# Altium schematic sheet ESPLink.SchDoc
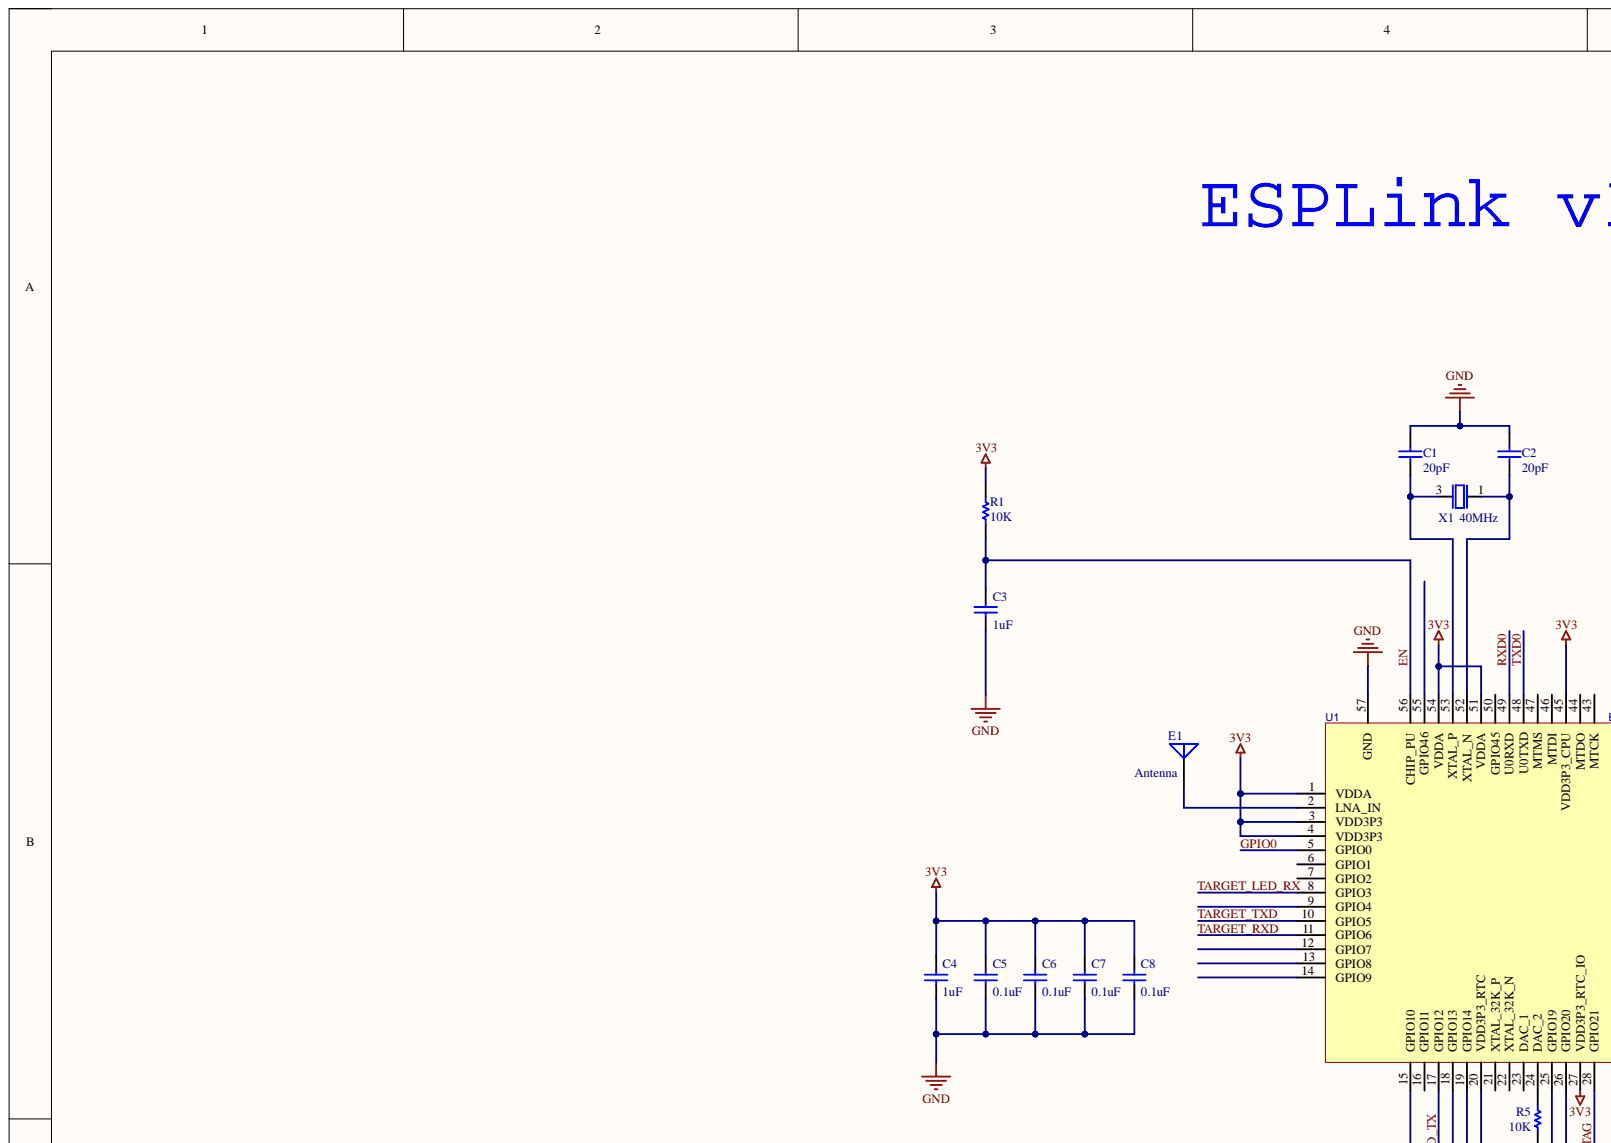
[diagram p0: ESPLink.SchDoc, top left]
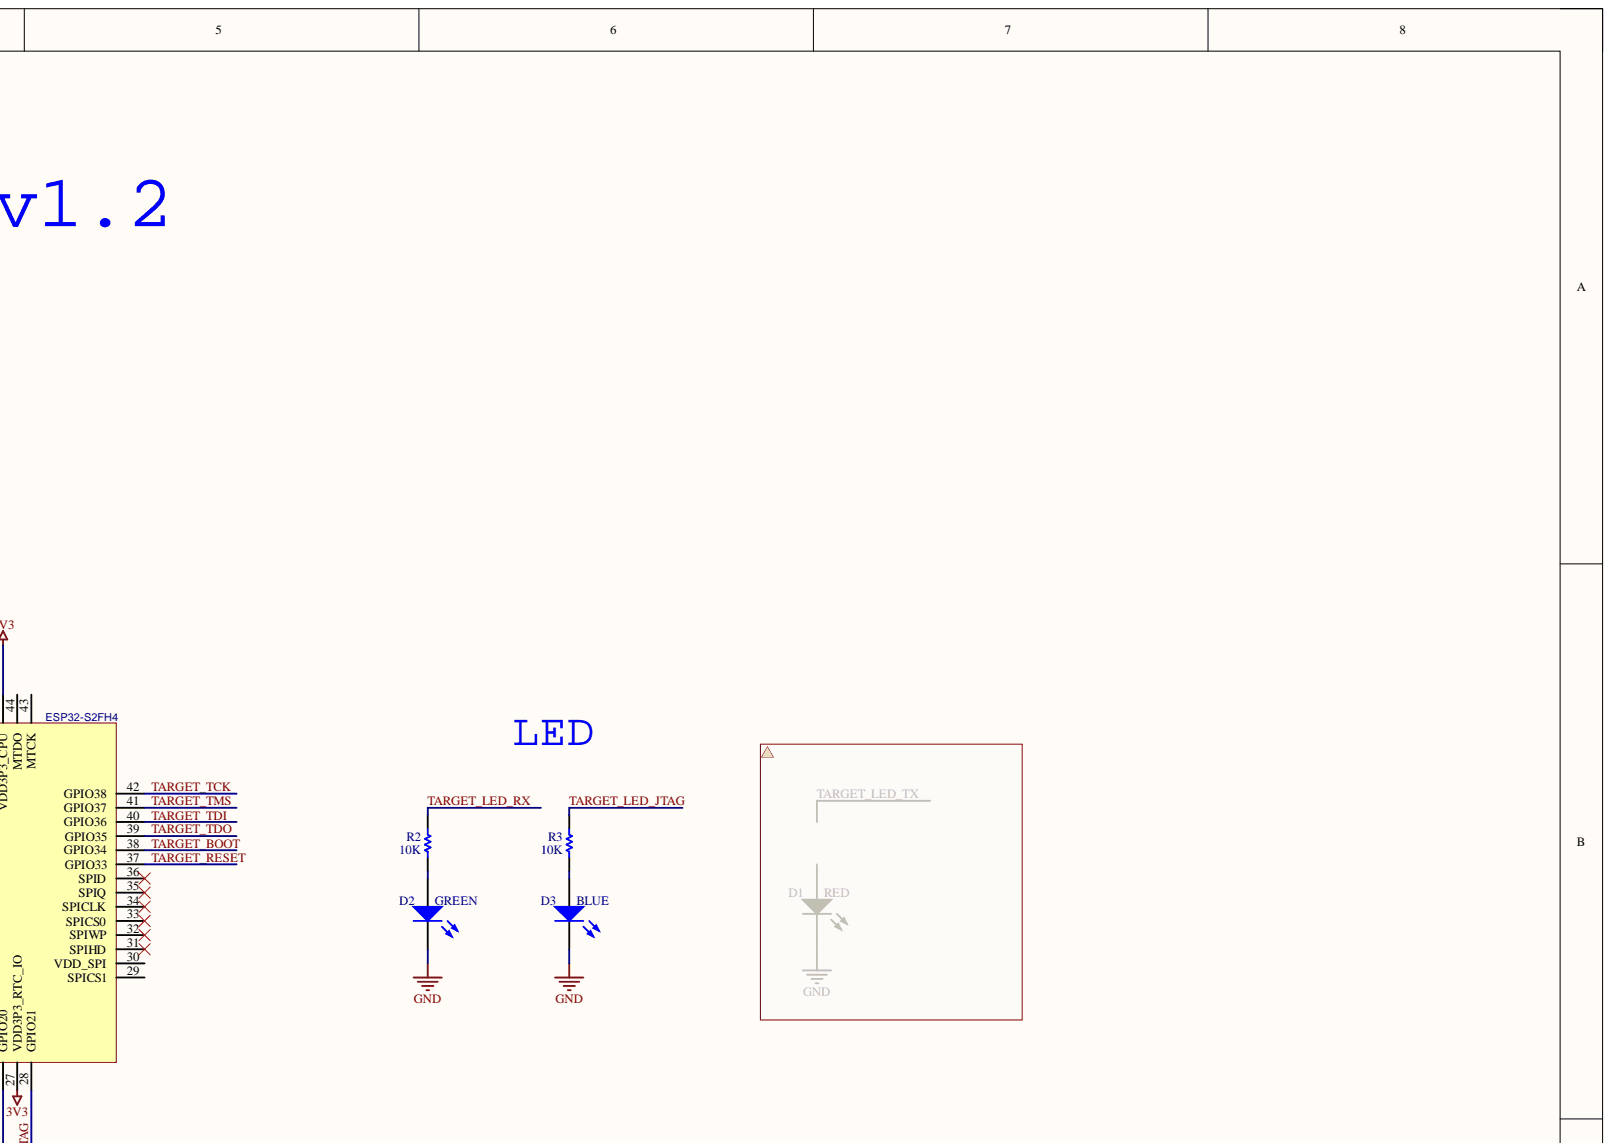
[diagram p1: ESPLink.SchDoc, top right]
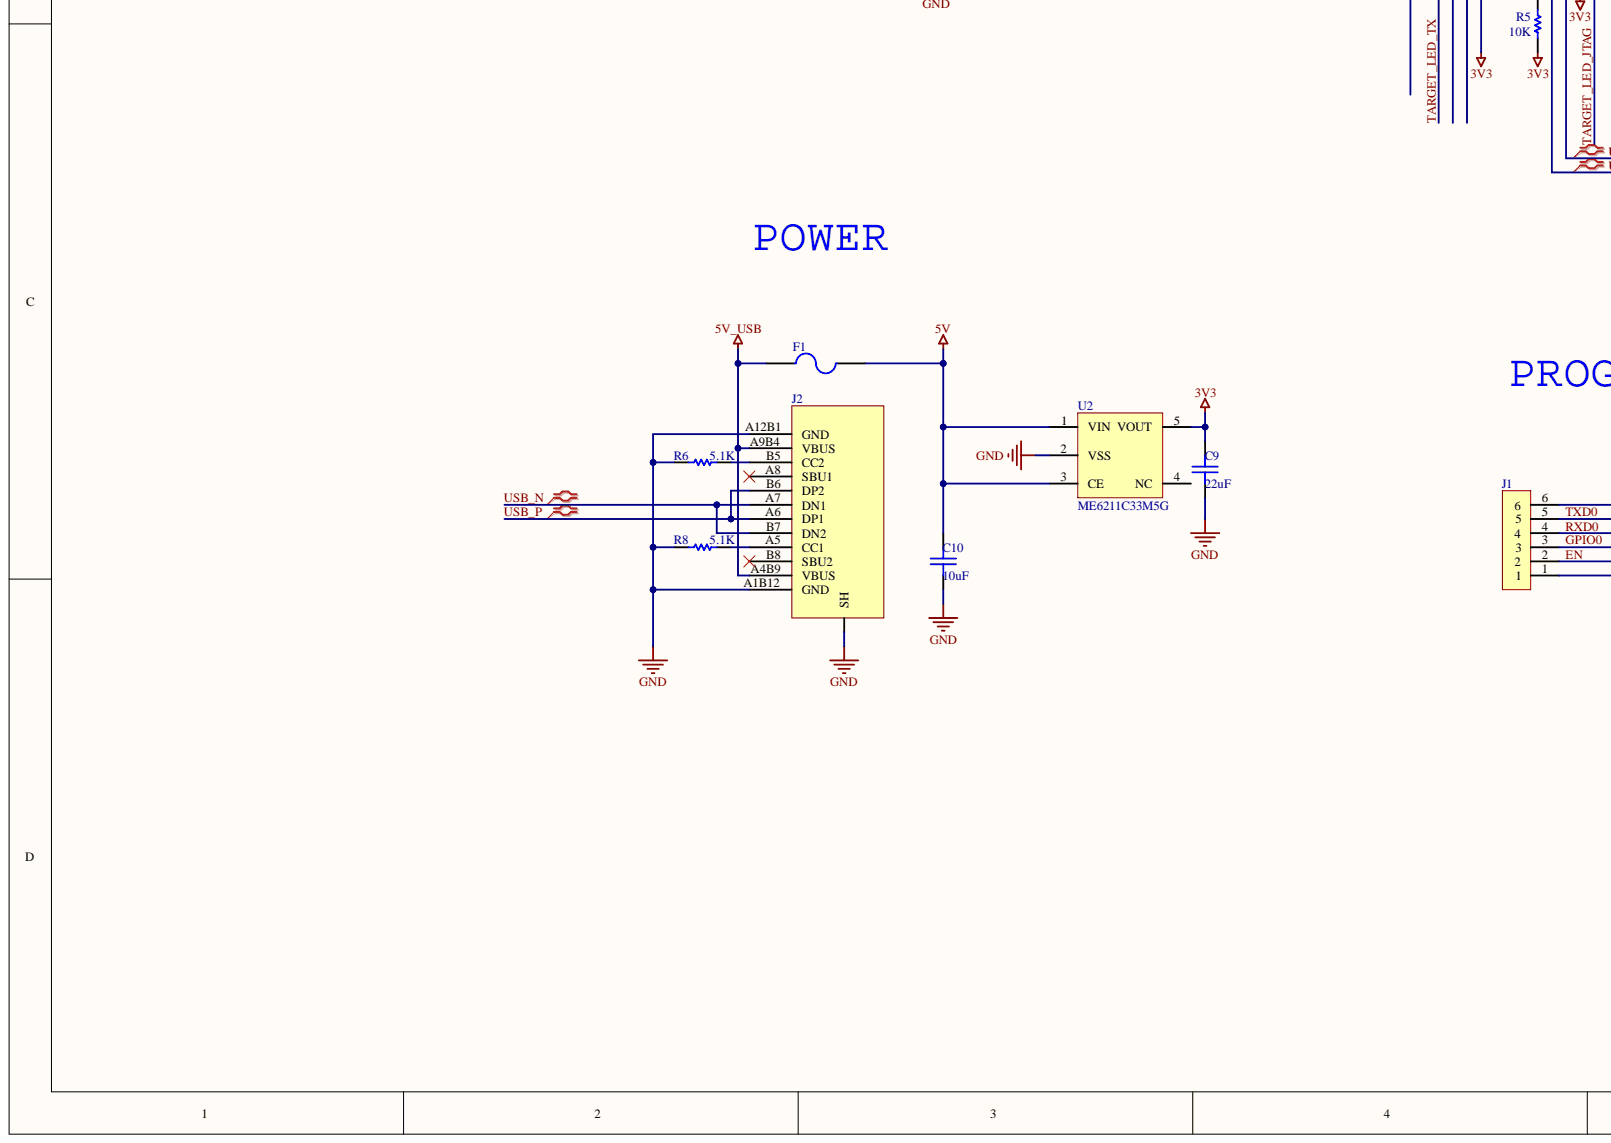
[diagram p2: ESPLink.SchDoc, bottom left]
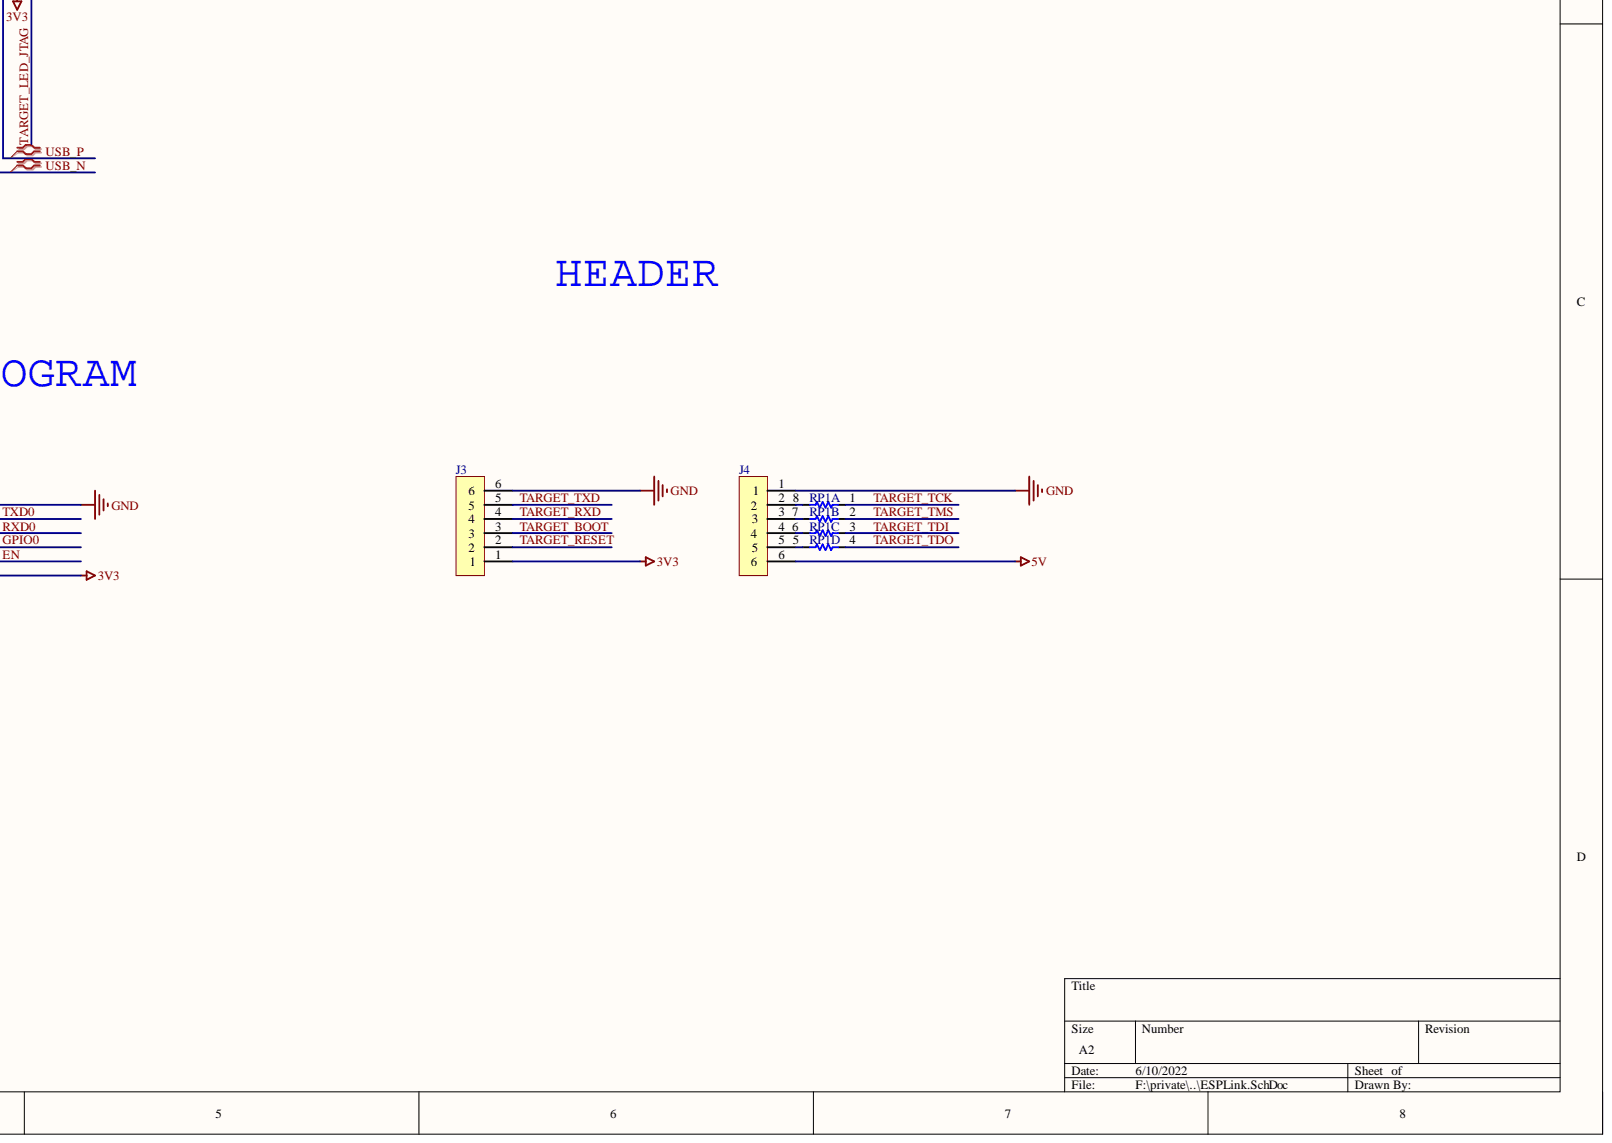
[diagram p3: ESPLink.SchDoc, bottom right]

## Parts
C1  20pF  [C 0402_M]
C2  20pF  [C 0402_M]
C3  1uF  [C 0402_M]
C4  1uF  [C 0402_M]
C5  0.1uF  [C 0402_M]
C6  0.1uF  [C 0402_M]
C7  0.1uF  [C 0402_M]
C8  0.1uF  [C 0402_M]
C9  22uF  [0603_C]
C10  10uF  [0603_C]
D2  GREEN  [0603_LED]
D3  BLUE  [0603_LED]
E1  Antenna  [ANT-Rainsun-AN2051]
F1  Fuse 2  [FU 0805]  (value not drawn)
J1  HEADER-6  [HEADER_1.27_SMT_6x1]  (value not drawn)
J2  Type_C_16P  [Type_C_16P]  (value not drawn)
J3  HEADER-6  [HEADER_SMT_6]  (value not drawn)
J4  HEADER-6  [HEADER_SMT_6]  (value not drawn)
R1  value 10K  [R 0402_M]  (hidden comment: R0603)
R2  10K  [R 0402_M]
R3  10K  [R 0402_M]
R5  value 10K  [R 0402_M]  (hidden comment: R0603)
R6  5.1K  [R 0402_M]
R8  5.1K  [R 0402_M]
RP1  R_pack  [RCA-8P4R-0402]  (value not drawn)  (parts A,B,C,D)
U1  ESP32-S2FH4  [QFN-56_L7.0-W7.0-P0.40-TL-EP4.0]
U2  ME6211C33M5G  [SOT23-5]
X1  40MHz  [BT-XTAL_3225]

## Nets
3V3: C4.2 C5.2 C6.2 C7.2 C8.2 C9.1 J1.1 J3.1 R1.1 R5.2 U1.1(VDDA) U1.3(VDD3P3) U1.4(VDD3P3) U1.20(VDD3P3_RTC) U1.27(VDD3P3_RTC_IO) U1.45(VDD3P3_CPU) U1.51(VDDA) U1.54(VDDA) U2.5(VOUT)
5V: C10.1 F1.2 J4.6 U2.1(VIN) U2.3(CE)
5V_USB: F1.1 J2.A4B9(VBUS) J2.A9B4(VBUS)
GND: C1.2 C2.2 C3.1 C4.1 C5.1 C6.1 C7.1 C8.1 C9.2 C10.2 D2.2 D3.2 J1.6 J2.0(SH) J2.A1B12(GND) J2.A12B1(GND) J3.6 J4.1 R6.1 R8.1 U1.57(GND) U2.2(VSS) X1.2 X1.4
EN: C3.2 J1.2 R1.2 U1.56(CHIP_PU)
GPIO0: J1.3 U1.5(GPIO0)
RXD0: J1.4 U1.49(U0RXD)
TARGET_BOOT: J3.3 U1.38(GPIO34)
TARGET_LED_JTAG: R3.1 U1.28(GPIO21)
TARGET_LED_RX: R2.1 U1.8(GPIO3)
TARGET_LED_TX: U1.17(GPIO12)
TARGET_RESET: J3.2 U1.37(GPIO33)
TARGET_RXD: J3.4 U1.11(GPIO6)
TARGET_TCK: RP1.1 U1.42(GPIO38)
TARGET_TDI: RP1.3 U1.40(GPIO36)
TARGET_TDO: RP1.4 U1.39(GPIO35)
TARGET_TMS: RP1.2 U1.41(GPIO37)
TARGET_TXD: J3.5 U1.10(GPIO5)
TXD0: J1.5 U1.48(U0TXD)
USB_N: J2.A7(DN1) J2.B7(DN2) U1.25(GPIO19)
USB_P: J2.A6(DP1) J2.B6(DP2) U1.26(GPIO20)
NetC1_1: C1.1 U1.53(XTAL_P) X1.3
NetC2_1: C2.1 U1.52(XTAL_N) X1.1
NetD2_1: D2.1 R2.2
NetD3_1: D3.1 R3.2
NetE1_1: E1.1 U1.2(LNA_IN)
NetJ2_A5: J2.A5(CC1) R8.2
NetJ2_B5: J2.B5(CC2) R6.2
NetJ4_2: J4.2 RP1.8
NetJ4_3: J4.3 RP1.7
NetJ4_4: J4.4 RP1.6
NetJ4_5: J4.5 RP1.5
NetR5_1: R5.1 U1.24(DAC_2)

Not connected: J2 A8(SBU1) B8(SBU2); U1 6(GPIO1) 7(GPIO2) 9(GPIO4) 12(GPIO7) 13(GPIO8) 14(GPIO9) 15(GPIO10) 16(GPIO11) 18(GPIO13) 19(GPIO14) 21(XTAL_32K_P) 22(XTAL_32K_N) 23(DAC_1) 29(SPICS1) 30(VDD_SPI) 31(SPIHD) 32(SPIWP) 33(SPICS0) 34(SPICLK) 35(SPIQ) 36(SPID) 43(MTCK) 44(MTDO) 46(MTDI) 47(MTMS) 50(GPIO45) 55(GPIO46); U2 4(NC)

## Library data of the parts
C1-C8 = C0603: Capacitor; Component Kind: Standard; LatestRevisionDate: 17-Jul-2002; LatestRevisionNote: Re-released for DXP Platform.; Library Name: MYLIB.IntLib; PackageDescription: Radial Cap, Thru-Hole; 2 Leads; 0.3 in Pin Spacing; PackageReference: RAD-0.3; Published: 8-Jun-2000; Signal Integrity: Cap; Simulation: CAP; Publisher: Altium Limited
  C1 C2: Value: 20pF
  C3 C4: Value: 1uF
  C5-C8: Value: 0.1uF
C9 C10 = SMT_C_0805: Surface mount capacitor 0805; Component Kind: Standard; Library Name: Vowstar_Basic_Device.IntLib
D2 D3 = D0603: Typical RED, GREEN, YELLOW, AMBER GaAs LED; Component Kind: Standard; LatestRevisionDate: 17-Jul-2002; LatestRevisionNote: Re-released for DXP Platform.; Library Name: MYLIB.IntLib; PackageDescription: SM LED; 2 Flat Leads; PackageReference: 3.2X1.6X1.1; PackageVersion: Feb-2002; Published: 8-Jun-2000; Simulation: LED2; Publisher: Altium Limited
E1 = Antenna: Generic Antenna; Component Kind: Standard; LatestRevisionDate: 17-Jul-2002; LatestRevisionNote: Re-released for DXP Platform.; Library Name: Miscellaneous Devices.IntLib; PackageReference: PIN1; Published: 24-Mar-1999; Publisher: Altium Limited
F1 = Fuse 2: Fuse; Component Kind: Standard; LatestRevisionDate: 17-Jul-2002; LatestRevisionNote: Re-released for DXP Platform.; Library Name: Miscellaneous Devices.IntLib; PackageDescription: Thru-Hole; 2 Leads; PackageReference: PIN-W2/E2.8; Published: 8-Jun-2000; Simulation: FUSE; Publisher: Altium Limited
R1-R3 R5 R6 R8 = R0603: Resistor; Component Kind: Standard; LatestRevisionDate: 17-Jul-2002; LatestRevisionNote: Re-released for DXP Platform.; Library Name: MYLIB.IntLib; PackageDescription: Axial Device, Thru-Hole; 2 Leads; 0.3 in Pin Spacing; PackageReference: AXIAL-0.3; Published: 8-Jun-2000; Signal Integrity: Res; Simulation: RESISTOR; Publisher: Altium Limited
  R2 R3: Value: 10K
  R6 R8: Value: 5.1K
U1 = ESP32-S2FH4: BOM_Manufacturer: ESPRESSIF 乐鑫; Contributor: LCSC; BOM_JLCPCB Part Class: Extended Part; BOM_Manufacturer Part: ESP32-S2FH4; BOM_Supplier: LCSC; BOM_Supplier Part: C2840995; Component Kind: Standard; Library Name: ESPLink.SCHLIB; spicePre: U; spiceSymbolName: ESP32-S2FH4
X1 = XTAL SMD 12MHz (3225): XTAL SMD 3225, 8MHz, 18pF, ±30ppm; 2nd source part number: 184-2056; Manufacturer: TXC Corporation; Manufacturer Part Number: 7M-12.000MAAJ-T; Artikkelnr Noca: 22019166; Artikkelnr Simpro: N.A.; Database Table Name: Crystal_Osc$; Note: XTAL SMD 12.000MHz; 2nd source Supplier: Farnell; Component Kind: Standard; Datasheet: http://www.txccrystal.com/images/pdf/7m.pdf; Footprint Doc: BT-XTAL_3225; LatestRevisionDate: 2010-08-31; Library Name: Nordic Discrete DB.DbLib; Part Number: XTAL SMD 12MHz (3225); Pin Count: 4; Published: 2010-08-31; Supplier: Digi-Key; Supplier part number: 887-1121-1-ND; Value: 40MHz; Publisher: Nordic Semiconductor
J1 J3 J4 = HEADER-6: Component Kind: Standard
J2 = Type_C_16P: Component Kind: Standard; Library Name: ICESugar.SCHLIB
RP1 = R_pack: Component Kind: Standard; Library Name: nanoESP32S2.SCHLIB
U2 = ME6211C33M5G: Component Kind: Standard
